# Altium schematic sheet nrf51x22.SchDoc(nrf51x22)
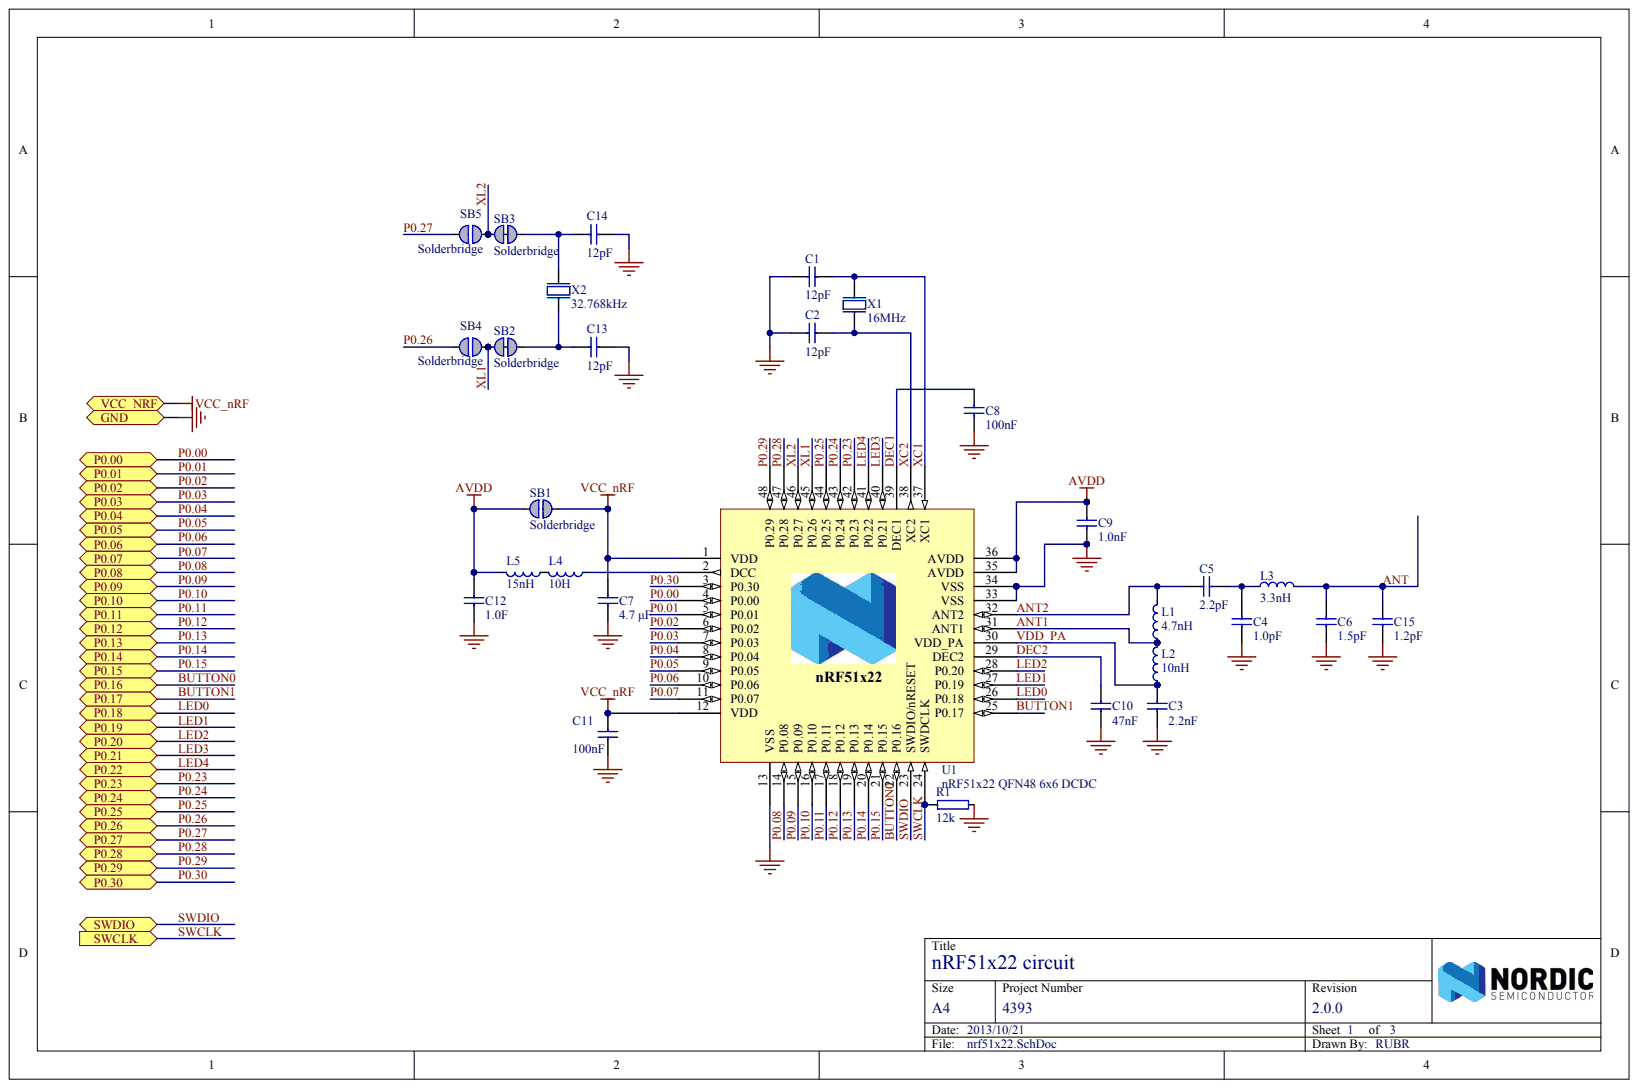
[diagram p0: nrf51x22.SchDoc]

## Parts
C1  12pF  [0402]
C2  12pF  [0402]
C3  2.2nF  [0402]
C4  1.0pF  [0402]
C5  2.2pF  [0402]
C6  1.5pF  [0402]
C7  4.7 μF  [0603]
C8  100nF  [0402]
C9  1.0nF  [0402]
C10  47nF  [0402]
C11  100nF  [0402]
C12  1.0µF  [0603]
C13  12pF  [0402]
C14  12pF  [0402]
C15  1.2pF  [0402]
L1  4.7nH  [0402]
L2  10nH  [0402]
L3  3.3nH  [0402]
L4  10µH  [0603]
L5  15nH  [0402]
R1  12k  [0402]
SB1  Solderbridge  [Solderbridge]
SB2  Solderbridge  [Solderbridge_Shorted]
SB3  Solderbridge  [Solderbridge_Shorted]
SB4  Solderbridge  [Solderbridge]
SB5  Solderbridge  [Solderbridge]
U1  nRF51x22 QFN48 6x6 DCDC  [QFN-48]
X1  16MHz  [BT-XTAL_2520]
X2  32.768kHz  [FC-135]

## Ports
GND, P0.00, P0.01, P0.02, P0.03, P0.04, P0.05, P0.06, P0.07, P0.08, P0.09, P0.10, P0.11, P0.12, P0.13, P0.14, P0.15, P0.16, P0.17, P0.18, P0.19, P0.20, P0.21, P0.22, P0.23, P0.24, P0.25, P0.26, P0.27, P0.28, P0.29, P0.30, SWCLK, SWDIO, VCC_NRF

## Nets
AVDD: C9.1 C12.2 L5.2 SB1.2 U1.35(AVDD) U1.36(AVDD)
VCC_NRF: C7.1 C11.1 SB1.1 U1.1(VDD) U1.12(VDD) ; port VCC_NRF
ANT: C6.1 C15.1 L3.2
ANT1: L1.1 L2.2 U1.31(ANT1)
ANT2: C5.1 L1.2 U1.32(ANT2)
DEC1: C8.1 U1.39(DEC1)
DEC2: C10.1 U1.29(DEC2)
GND: C1.2 C2.2 C3.2 C4.2 C6.2 C7.2 C8.2 C9.2 C10.2 C11.2 C12.1 C13.2 C14.2 C15.2 R1.1 U1.13(VSS) U1.33(VSS) U1.34(VSS) U1.49 X1.2 X1.4 ; port GND
P0.00: U1.4(P0.00) ; port P0.00
P0.01: U1.5(P0.01) ; port P0.01
P0.02: U1.6(P0.02) ; port P0.02
P0.03: U1.7(P0.03) ; port P0.03
P0.04: U1.8(P0.04) ; port P0.04
P0.05: U1.9(P0.05) ; port P0.05
P0.06: U1.10(P0.06) ; port P0.06
P0.07: U1.11(P0.07) ; port P0.07
P0.08: U1.14(P0.08) ; port P0.08
P0.09: U1.15(P0.09) ; port P0.09
P0.10: U1.16(P0.10) ; port P0.10
P0.11: U1.17(P0.11) ; port P0.11
P0.12: U1.18(P0.12) ; port P0.12
P0.13: U1.19(P0.13) ; port P0.13
P0.14: U1.20(P0.14) ; port P0.14
P0.15: U1.21(P0.15) ; port P0.15
P0.16: U1.22(P0.16) ; port P0.16
P0.17: U1.25(P0.17) ; port P0.17
P0.18: U1.26(P0.18) ; port P0.18
P0.19: U1.27(P0.19) ; port P0.19
P0.20: U1.28(P0.20) ; port P0.20
P0.21: U1.40(P0.21) ; port P0.21
P0.22: U1.41(P0.22) ; port P0.22
P0.23: U1.42(P0.23) ; port P0.23
P0.24: U1.43(P0.24) ; port P0.24
P0.25: U1.44(P0.25) ; port P0.25
P0.26: SB4.2 ; port P0.26
P0.27: SB5.2 ; port P0.27
P0.28: U1.47(P0.28) ; port P0.28
P0.29: U1.48(P0.29) ; port P0.29
P0.30: U1.3(P0.30) ; port P0.30
SWCLK: R1.2 U1.24(SWDCLK) ; port SWCLK
SWDIO: U1.23(SWDIO/nRESET) ; port SWDIO
VDD_PA: C3.1 L2.1 U1.30(VDD_PA)
XC1: C1.1 U1.37(XC1) X1.1
XC2: C2.1 U1.38(XC2) X1.3
XL1: SB2.2 SB4.1 U1.45(P0.26)
XL2: SB3.2 SB5.1 U1.46(P0.27)
NetC4_1: C4.1 C5.2 L3.1
NetC13_1: C13.1 SB2.1 X2.1
NetC14_1: C14.1 SB3.1 X2.2
NetL4_1: L4.1 U1.2(DCC)
NetL4_2: L4.2 L5.1

## Library data of the parts
C1 C2 C13 C14 = (no symbol name): Part Number: C0402-12p; Note: 50V; Artikkelnr Noca: 20029159; Manufacturer: *; Manufacturer Part Number: *; Datasheet: *; LatestRevisionDate: 2011-05-11; Pin Count: 2; Published: 2009-05-08; Value: 12pF; Supplier: *; Supplier part number: *; 2nd source Supplier: *; 2nd source part number: *; Publisher: Nordic Semiconductor
C3 = (no symbol name): Part Number: C0402-2n2; Note: 50V; Artikkelnr Noca: 20039221; Manufacturer: *; Manufacturer Part Number: *; Datasheet: *; LatestRevisionDate: 2009-11-23; Pin Count: 2; Published: 2009-05-08; Value: 2.2nF; Supplier: *; Supplier part number: *; 2nd source Supplier: *; 2nd source part number: *; Publisher: Nordic Semiconductor
C4 = (no symbol name): Part Number: C0402-0.8p; Note: 50V; Artikkelnr Noca: 20029147; Manufacturer: *; Manufacturer Part Number: *; Datasheet: *; LatestRevisionDate: 2012-11-21; Pin Count: 2; Published: 2009-05-08; Value: 1.0pF; Supplier: *; Supplier part number: *; 2nd source Supplier: *; 2nd source part number: *; Publisher: Nordic Semiconductor
C5 = (no symbol name): Part Number: C0402-2.2p; Note: 50V; Artikkelnr Noca: 20029145; Manufacturer: *; Manufacturer Part Number: *; Datasheet: *; LatestRevisionDate: 2009-05-08; Pin Count: 2; Published: 2009-05-08; Value: 2.2pF; Supplier: *; Supplier part number: *; 2nd source Supplier: *; 2nd source part number: *; Publisher: Nordic Semiconductor
C6 = (no symbol name): Part Number: C0402-1.5p; Note: 50V; Artikkelnr Noca: 20029139; Manufacturer: *; Manufacturer Part Number: *; Datasheet: *; LatestRevisionDate: 2009-11-23; Pin Count: 2; Published: 2009-05-08; Value: 1.5pF; Supplier: *; Supplier part number: *; 2nd source Supplier: *; 2nd source part number: *; Publisher: Nordic Semiconductor
C7 = (no symbol name): Part Number: C0603-4?; Note: 6.3V; Artikkelnr Noca: 20069060; Manufacturer: *; Manufacturer Part Number: *; Datasheet: *; LatestRevisionDate: 2009-11-23; Pin Count: 2; Published: 2009-05-08; Value: 4.7 μF; Supplier: *; Supplier part number: *; 2nd source Supplier: *; 2nd source part number: *; Publisher: Nordic Semiconductor
C8 C11 = (no symbol name): Part Number: C0402-100n; Note: 16V; Artikkelnr Noca: 20039200; Manufacturer: *; Manufacturer Part Number: *; Datasheet: *; LatestRevisionDate: 2009-11-23; Pin Count: 2; Published: 2009-05-08; Value: 100nF; Supplier: *; Supplier part number: *; 2nd source Supplier: *; 2nd source part number: *; Publisher: Nordic Semiconductor
C9 = (no symbol name): Part Number: C0402-1n0; Note: 50V; Artikkelnr Noca: 20039223; Manufacturer: *; Manufacturer Part Number: *; Datasheet: *; LatestRevisionDate: 2009-11-23; Pin Count: 2; Published: 2009-05-08; Value: 1.0nF; Supplier: *; Supplier part number: *; 2nd source Supplier: *; 2nd source part number: *; Publisher: Nordic Semiconductor
C10 = (no symbol name): Part Number: C0402-47n; Note: Chip Cap 10%; Artikkelnr Noca: 20039389; Manufacturer: *; Manufacturer Part Number: *; Datasheet: *; LatestRevisionDate: 2009-05-08; Pin Count: 2; Published: 2009-05-08; Value: 47nF; Supplier: *; Supplier part number: *; 2nd source Supplier: *; 2nd source part number: *; Publisher: Nordic Semiconductor
C12 = (no symbol name): Part Number: C0603-1? X7R; Value: 1.0礔; Note: 16V; Artikkelnr Noca: 20039335; Manufacturer: *; Manufacturer Part Number: *; Datasheet: *; LatestRevisionDate: 2010-02-24; Pin Count: 2; Published: 2010-02-24; Supplier: *; Supplier part number: *; 2nd source Supplier: *; 2nd source part number: *; Publisher: Nordic Semiconductor
C15 = (no symbol name): Part Number: C0402-1.2p; Note: 50V; Artikkelnr Noca: 20029157; Manufacturer: *; Manufacturer Part Number: *; Datasheet: *; LatestRevisionDate: 2011-05-11; Pin Count: 2; Published: 2009-05-08; Value: 1.2pF; Supplier: *; Supplier part number: *; 2nd source Supplier: *; 2nd source part number: *; Publisher: Nordic Semiconductor
L1 = (no symbol name): Part Number: L0402-4n7; Manufacturer: Murata; Manufacturer Part Number: LQP15MN4N7B02D; Note: High frequency chip inductor ?%; Artikkelnr Noca: 33029164; Datasheet: http://search.murata.co.jp/Ceramy/image/img/PDF/ENG/L0075S0084LQP15M.pdf; LatestRevisionDate: 2012-09-19; Pin Count: 2; Published: 2009-05-08; Value: 4.7nH; Supplier: Digi-Key; Supplier part number: 490-1132-1-ND; 2nd source Supplier: *; 2nd source part number: *; Publisher: Nordic Semiconductor
L2 = (no symbol name): Part Number: L0402-10n; Manufacturer: Murata; Manufacturer Part Number: LQP15MN10NG02D; Note: High frequency chip inductor ?%; Artikkelnr Noca: 33029425; Datasheet: http://search.murata.co.jp/Ceramy/image/img/PDF/ENG/L0075S0084LQP15M.pdf; LatestRevisionDate: 2012-09-19; Pin Count: 2; Published: 2010-07-12; Value: 10nH; Supplier: Mouser; Supplier part number: 81-LQP15MN10NG02D; 2nd source Supplier: *; 2nd source part number: *; Publisher: Nordic Semiconductor
L3 = (no symbol name): Part Number: L0402-3n3; Manufacturer: Murata; Manufacturer Part Number: LQP15MN3N3B02D; Note: High frequency chip inductor ?%; Artikkelnr Noca: 33029369; Datasheet: http://search.murata.co.jp/Ceramy/image/img/PDF/ENG/L0075S0084LQP15M.pdf; LatestRevisionDate: 2012-09-19; Pin Count: 2; Published: 2009-05-08; Value: 3.3nH; Supplier: Digi-Key; Supplier part number: 490-1130-1-ND; 2nd source Supplier: *; 2nd source part number: *; Publisher: Nordic Semiconductor
L4 = (no symbol name): Part Number: L0603-10祙; Manufacturer: Taiyo Yuden; Manufacturer Part Number: LBMF1608T100K; 2nd source part number: 178-6105; Value: 10礖; Note: LBMF1608T100K 10礖 80mA 0603; Artikkelnr Noca: 33029314; Datasheet: http://www.yuden.co.jp/ut/product/pdf/elb2_e.pdf; LatestRevisionDate: 2012-09-19; Pin Count: 2; Published: 2010-02-24; Supplier: Digi-Key; Supplier part number: 587-1714-1-ND; 2nd source Supplier: Farnell; Publisher: Nordic Semiconductor
L5 = (no symbol name): Part Number: L0402-15n; Manufacturer: Taiyo Yuden; Manufacturer Part Number: HK100515NJ-T; 2nd source part number: 658-1328; Note: High frequency chip inductor ?0%; Artikkelnr Noca: 33029323; LatestRevisionDate: 2012-09-19; Pin Count: 2; Published: 2010-07-12; Value: 15nH; Supplier: Digi-Key; Datasheet: http://www.yuden.co.jp/productdata/catalog/en/mlci04_e.pdf; Supplier part number: 587-1521-1-ND; 2nd source Supplier: Farnell; Publisher: Nordic Semiconductor
R1 = (no symbol name): Part Number: R0402-12k; Note: Chip Res 1%; Artikkelnr Noca: 27049099; Manufacturer: *; Manufacturer Part Number: *; Datasheet: *; LatestRevisionDate: 2009-11-23; Pin Count: 2; Published: 2009-05-08; Value: 12k; Supplier: Farnell; Supplier part number: 173-8865; 2nd source Supplier: *; 2nd source part number: *; Publisher: Nordic Semiconductor
SB1 SB4 SB5 = (no symbol name): Artikkelnr Noca: N.A.; Part Number: Solderbridge; Manufacturer: *; Manufacturer Part Number: *; Datasheet: *; LatestRevisionDate: 2009-12-14; Pin Count: 2; Published: 2009-12-14; Value: Solderbridge; Note: *; Supplier: *; Supplier part number: *; 2nd source Supplier: *; 2nd source part number: *; Publisher: Nordic Semiconductor
SB2 SB3 = (no symbol name): Part Number: Solderbridge Shorted; Artikkelnr Noca: N.A.; Manufacturer: *; Manufacturer Part Number: *; Datasheet: *; LatestRevisionDate: 2012-08-07; Pin Count: 2; Published: 2012-08-07; Value: Solderbridge; Note: *; Supplier: *; Supplier part number: *; 2nd source Supplier: *; 2nd source part number: *; Publisher: Nordic Semiconductor
U1 = (no symbol name): Part Number: nRF51x22-Q48-6x6_DCDC; Manufacturer: Nordic Semiconductor; Note: nRF51x22 - QFN48 6x6 0.4mm pitch; Artikkelnr Noca: N.A.; Manufacturer Part Number: *; Datasheet: *; LatestRevisionDate: 2012-05-29; Pin Count: 48; Published: 2010-12-21; Value: nRF51x22 QFN48 6x6 DCDC; Supplier: Nordic Semiconductor; Supplier part number: *; 2nd source Supplier: *; 2nd source part number: *; Publisher: Nordic Semiconductor
X1 = (no symbol name): Part Number: NX2520SA-16MHZ-STD-CSW-5; Manufacturer: NDK; Note: XTAL SMD 16.000MHz; Artikkelnr Noca: 22019192; Manufacturer Part Number: NX2520SA-16MHZ-STD-CSW-5; Datasheet: http://www.ndk.com/images/products/catalog/c_NX2520SA-STD-CSW-5_e.pdf; LatestRevisionDate: 2012-06-15; Pin Count: 4; Published: 2012-06-15; Value: 16MHz; Supplier: Digi-Key; Supplier part number: 644-1169-1-ND; 2nd source Supplier: *; 2nd source part number: *; Publisher: Nordic Semiconductor
X2 = (no symbol name): Part Number: XTAL_FC-135 32.768k; Manufacturer: Epson Toyocom; Manufacturer Part Number: FC-135 32.768KHZ ?0PPM,9.0PF; 2nd source part number: 127-8037; Note: XTAL SMD 32.768kHz, 3215; Artikkelnr Noca: 22019091; Datasheet: http://www.farnell.com/datasheets/57480.pdf; LatestRevisionDate: 2010-10-18; Pin Count: 2; Published: 2010-10-18; Value: 32.768kHz; Supplier: Digi-Key; Supplier part number: FC-135 32.7680KA-AC-ND; 2nd source Supplier: Farnell; Publisher: Nordic Semiconductor
